# Altium schematic sheet BMS Slave Device R1.5.SchDoc
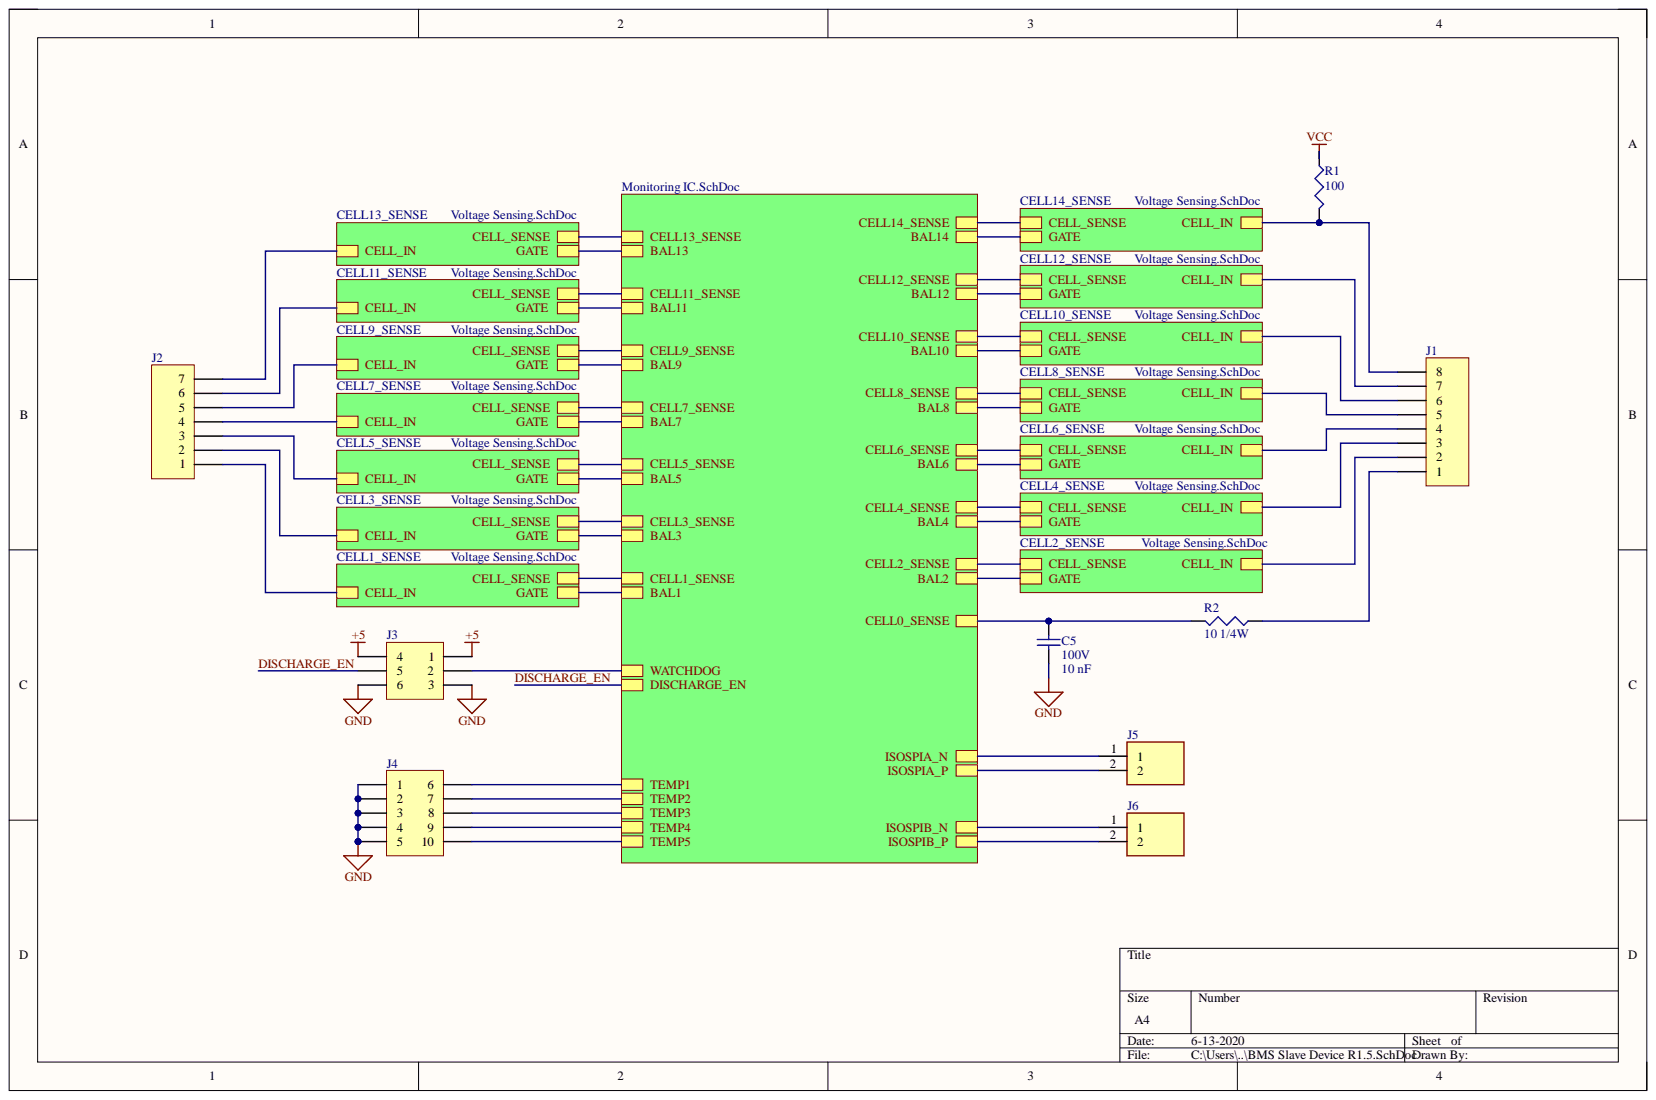
[diagram p0: BMS Slave Device R1.5.SchDoc]

## Parts
C5  100V  100V: 10 nF  [6-0805_N]
J1  Header 8  [HDR1X8]  (value not drawn)
J2  ODD CELLS  [HDR1X7]  (value not drawn)
J3  (no value)  [HDR2X3_CEN]
J4  THERMISTORS  [HDR2X5_CEN]  (value not drawn)
J5  B2B-XH-2__LF__SN_  [B2BXH2LFSN]  (value not drawn)
J6  B2B-XH-2__LF__SN_  [B2BXH2LFSN]  (value not drawn)
R1  value 100  [J1-0603]  (hidden comment: Res3)
R2  value 10 1/4W  [C1206]

## Nets
+5: J3.1 J3.4
GND: C5.1 J3.3 J3.6 J4.1 J4.2 J4.3 J4.4 J4.5
VCC: R1.2
DISCHARGE_EN: J3.5
NetC5_2: C5.2 R2.1
NetJ1_1: J1.1 R2.2
NetJ1_2: J1.2
NetJ1_3: J1.3
NetJ1_4: J1.4
NetJ1_5: J1.5
NetJ1_6: J1.6
NetJ1_7: J1.7
NetJ1_8: J1.8 R1.1
NetJ2_1: J2.1
NetJ2_2: J2.2
NetJ2_3: J2.3
NetJ2_4: J2.4
NetJ2_5: J2.5
NetJ2_6: J2.6
NetJ2_7: J2.7
NetJ3_2: J3.2
NetJ4_6: J4.6
NetJ4_7: J4.7
NetJ4_8: J4.8
NetJ4_9: J4.9
NetJ4_10: J4.10
NetJ5_1: J5.1
NetJ5_2: J5.2
NetJ6_1: J6.1
NetJ6_2: J6.2
BAL1:
BAL2:
BAL3:
BAL4:
BAL5:
BAL6:
BAL7:
BAL8:
BAL9:
BAL10:
BAL11:
BAL12:
BAL13:
BAL14:
CELL1_SENSE:
CELL2_SENSE:
CELL3_SENSE:
CELL4_SENSE:
CELL5_SENSE:
CELL6_SENSE:
CELL7_SENSE:
CELL8_SENSE:
CELL9_SENSE:
CELL10_SENSE:
CELL11_SENSE:
CELL12_SENSE:
CELL13_SENSE:
CELL14_SENSE:

## Library data of the parts
C5 = Cap: Capacitor; Component Kind: Standard; LatestRevisionDate: 17-Jul-2002; LatestRevisionNote: Re-released for DXP Platform.; Library Name: Miscellaneous Devices.IntLib; PackageDescription: Radial Cap, Thru-Hole; 2 Leads; 0.3 in Pin Spacing; PackageReference: RAD-0.3; Published: 8-Jun-2000; Signal Integrity: Cap; Simulation: CAP; Publisher: Altium Limited
J1 = Header 8: Header, 8-Pin; Component Kind: Standard; LatestRevisionDate: 17-Jul-2002; LatestRevisionNote: Re-released for DXP Platform.; Library Name: Miscellaneous Connectors.IntLib; Signal Integrity: Connector; Publisher: Altium Limited
J2 = Header 7: Component Kind: Standard; Description: ODD CELLS; LatestRevisionDate: 17-Jul-2002; LatestRevisionNote: Re-released for DXP Platform.; Library Name: Miscellaneous Connectors.IntLib; Signal Integrity: Connector; Publisher: Altium Limited
J3 = Header 3X2A: Header, 3-Pin, Dual row; Component Kind: Standard; LatestRevisionDate: 17-Jul-2002; LatestRevisionNote: Re-released for DXP Platform.; Library Name: Miscellaneous Connectors.IntLib; Signal Integrity: Connector; Publisher: Altium Limited
J4 = Header 5X2A: Header, 5-Pin, Dual row; Component Kind: Standard; LatestRevisionDate: 17-Jul-2002; LatestRevisionNote: Re-released for DXP Platform.; Library Name: Miscellaneous Connectors.IntLib; Signal Integrity: Connector; Publisher: Altium Limited
J5 J6 = B2B-XH-2__LF__SN_: Connector; Manufacturer_Name: JST (JAPAN SOLDERLESS TERMINALS); Manufacturer_Part_Number: B2B-XH-2 (LF)(SN); Component Kind: Standard; Datasheet Link: http://uk.rs-online.com/web/p/products/1755297; Height: 7mm; Library Name: BMS Slave Device R1.SchLib; RS Part Number: 1755499; RS Price/Stock: http://uk.rs-online.com/web/p/products/1755499
R1 R2 = Res3: Resistor; Component Kind: Standard; LatestRevisionDate: 29-May-2009; LatestRevisionNote: IPC-7351 Footprint Added.; Library Name: Miscellaneous Devices.IntLib; PackageDescription: Chip Resistor; PackageReference: J1-0603; Published: 8-Jun-2000; Signal Integrity: Res; Simulation: RESISTOR; Publisher: Altium Limited
